# Altium schematic sheet wls2-mcu.SchDoc(wls2-mcu)
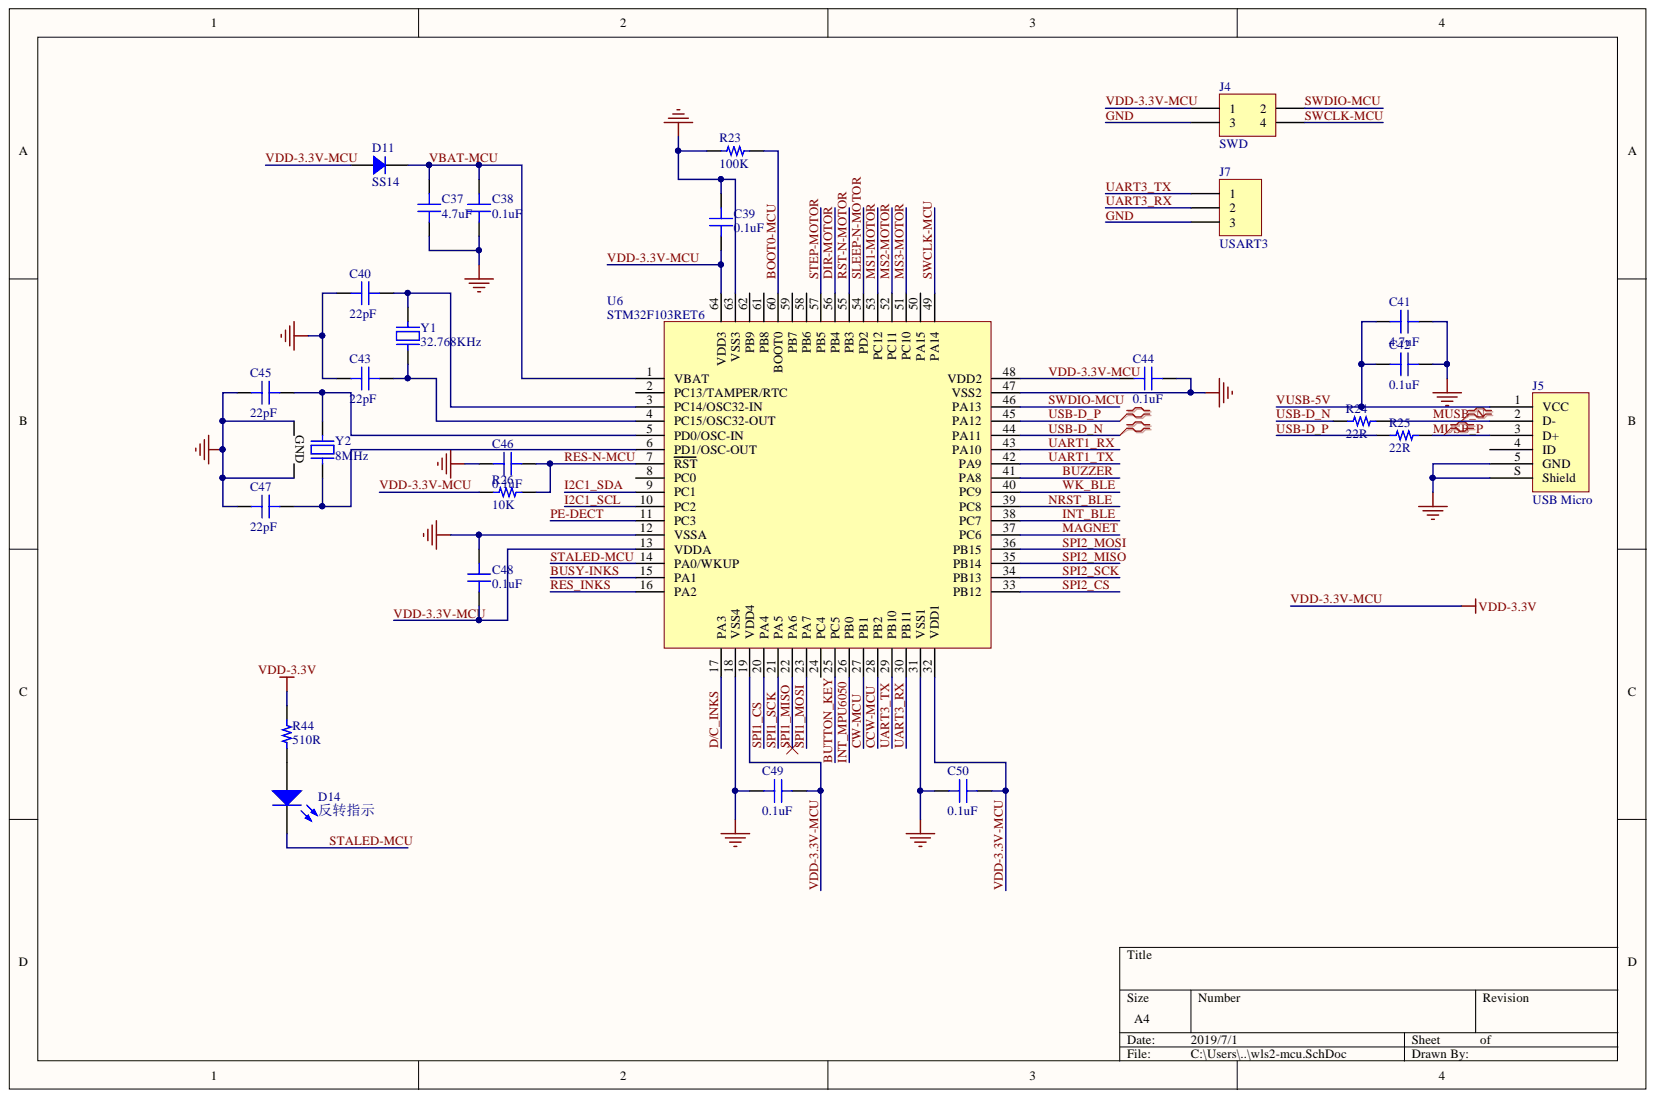
[diagram p0: wls2-mcu.SchDoc]

## Parts
C37  4.7uF  [CAP 0603/1608]
C38  0.1uF  [RES 0402/1005]
C39  0.1uF  [RES 0402/1005]
C40  22pF  [RES 0402/1005]
C41  4.7uF  [CAP 0603/1608]
C42  0.1uF  [RES 0402/1005]
C43  22pF  [RES 0402/1005]
C44  0.1uF  [RES 0402/1005]
C45  22pF  [RES 0402/1005]
C46  0.1uF  [RES 0402/1005]
C47  22pF  [RES 0402/1005]
C48  0.1uF  [RES 0402/1005]
C49  0.1uF  [RES 0402/1005]
C50  0.1uF  [RES 0402/1005]
D11  SS14  [SMA-DO214AC]
D14  反转指示  [LED 0805/2012 GREEN]
J4  SWD  [H2X2-2.54MM]
J5  USB Micro  [USB2.0-Micro-TypeB-04]
J7  USART3  [HDR1X3]
R23  100K  [RES 0402/1005]
R24  22R  [RES 0402/1005]
R25  22R  [RES 0402/1005]
R26  10K  [RES 0402/1005]
R44  510R  [RES 0402/1005]
U6  STM32F103RET6  [LQFP64P]
Y1  32.768KHz  [INDM2012X06N]
Y2  8MHz  [CRY_SMD3225_4PIN]

## Nets
BOOT0-MCU: R23.2 U6.60(BOOT0)
BUSY-INKS: U6.15(PA1)
BUTTON_KEY: U6.25(PC5)
BUZZER: U6.41(PA8)
CCW-MCU: U6.28(PB2)
CW-MCU: U6.27(PB1)
D/C_INKS: U6.17(PA3)
DIR-MOTOR: U6.56(PB4)
GND: C37.2 C38.1 C39.2 C40.1 C41.1 C42.1 C43.1 C44.2 C45.1 C46.1 C47.1 C48.2 C49.2 C50.2 J4.3 J5.5(GND) J5.S(Shield) J7.3 R23.1 U6.12(VSSA) U6.18(VSS4) U6.31(VSS1) U6.47(VSS2) U6.63(VSS3) Y2.2(GND) Y2.4
I2C1_SCL: U6.10(PC2)
I2C1_SDA: U6.9(PC1)
INT_BLE: U6.38(PC7)
INT_MPU6050: U6.26(PB0)
MAGNET: U6.37(PC6)
MS1-MOTOR: U6.53(PC12)
MS2-MOTOR: U6.52(PC11)
MS3-MOTOR: U6.51(PC10)
MUSB_N: J5.2(D-) R24.2
MUSB_P: J5.3(D+) R25.2
NRST_BLE: U6.39(PC8)
PE-DECT: U6.11(PC3)
RES-N-MCU: C46.2 R26.2 U6.7(RST)
RES_INKS: U6.16(PA2)
RST-N-MOTOR: U6.55(PB3)
SLEEP-N-MOTOR: U6.54(PD2)
SPI1_CS: U6.20(PA4)
SPI1_MISO: U6.22(PA6)
SPI1_MOSI: U6.23(PA7)
SPI1_SCK: U6.21(PA5)
SPI2_CS: U6.33(PB12)
SPI2_MISO: U6.35(PB14)
SPI2_MOSI: U6.36(PB15)
SPI2_SCK: U6.34(PB13)
STALED-MCU: D14.2 U6.14(PA0/WKUP)
STEP-MOTOR: U6.57(PB5)
SWCLK-MCU: J4.4 U6.49(PA14)
SWDIO-MCU: J4.2 U6.46(PA13)
UART1_RX: U6.43(PA10)
UART1_TX: U6.42(PA9)
UART3_RX: J7.2 U6.30(PB11)
UART3_TX: J7.1 U6.29(PB10)
USB-D_N: R24.1 U6.44(PA11)
USB-D_P: R25.1 U6.45(PA12)
VBAT-MCU: C37.1 C38.2 D11.2 U6.1(VBAT)
VDD-3.3V-MCU: C39.1 C44.1 C48.1 C49.1 C50.1 D11.1 J4.1 R26.1 R44.1 U6.13(VDDA) U6.19(VDD4) U6.32(VDD1) U6.48(VDD2) U6.64(VDD3)
VUSB-5V: C41.2 C42.2 J5.1(VCC)
WK_BLE: U6.40(PC9)
NetC40_2: C40.2 U6.3(PC14/OSC32-IN) Y1.2
NetC43_2: C43.2 U6.4(PC15/OSC32-OUT) Y1.1
NetC45_2: C45.2 U6.5(PD0/OSC-IN) Y2.1
NetC47_2: C47.2 U6.6(PD1/OSC-OUT) Y2.3
NetD14_1: D14.1 R44.2

Not connected: J5 4(ID); U6 2(PC13/TAMPER/RTC) 8(PC0) 24(PC4) 50(PA15) 58(PB6) 59(PB7) 61(PB8) 62(PB9)

## Library data of the parts
C37-C50 = Capacitor: SMT Capacitor; Component Kind: Standard; Library Name: Passives.SchLib
  C37 C41: Value: 4.7uF
  C38 C39 C42 C44 C46 C48-C50: Value: 0.1uF
  C40 C43 C45 C47: Value: 22pF
D11 = Diode: Rectifier Diode; Component Kind: Standard; Library Name: Diodes.SchLib; Part Number: SS14
D14 = LED0: 贴片指示灯; Component Kind: Standard; LatestRevisionDate: 08-Jul-2005; LatestRevisionNote: Mech Layer 1 removed.; Library Name: Miscellaneous Devices.IntLib; PackageReference: LED-0; Published: 8-Jun-2000; Simulation: LED0; Publisher: Altium Limited
J4 = Header 2X2: Header, 2-Pin, Dual row; Component Kind: Standard; LatestRevisionDate: 17-Jul-2002; LatestRevisionNote: Re-released for DXP Platform.; Library Name: Miscellaneous Connectors.IntLib; Signal Integrity: Connector; Publisher: Altium Limited
J5 = USB 2.0 Type B Micro: Component Kind: Standard; Library Name: Connectors.SchLib
J7 = Header 3: Header, 3-Pin; Component Kind: Standard; LatestRevisionDate: 17-Jul-2002; LatestRevisionNote: Re-released for DXP Platform.; Library Name: Miscellaneous Connectors.IntLib; Signal Integrity: Connector; Publisher: Altium Limited
R23-R26 R44 = Resistor: SMT Resistor; Component Kind: Standard; Library Name: Passives.SchLib
  R23: Value: 100K
  R24 R25: Value: 22R
  R26: Value: 10K
  R44: Value: 510R
U6 = STM32F103-LQFP64: Component Kind: Standard; Description: stm32f103ret6; Library Name: device_sch_lib.SchLib
Y1 = Abracon Crystal ABLS: SMT Crystal; Component Kind: Standard; Library Name: Crystals.SchLib; TYPE: 2012 FC-12M 32.768K; VALUE: 32.768KHz
Y2 = NDK Crystal NX3225GA: SMT Crystal; Component Kind: Standard; Library Name: Crystals.SchLib; TYPE: 8MHz SMD-5032; VALUE: 8MHz
